# Altium schematic sheet MCU.SchDoc(MCU)
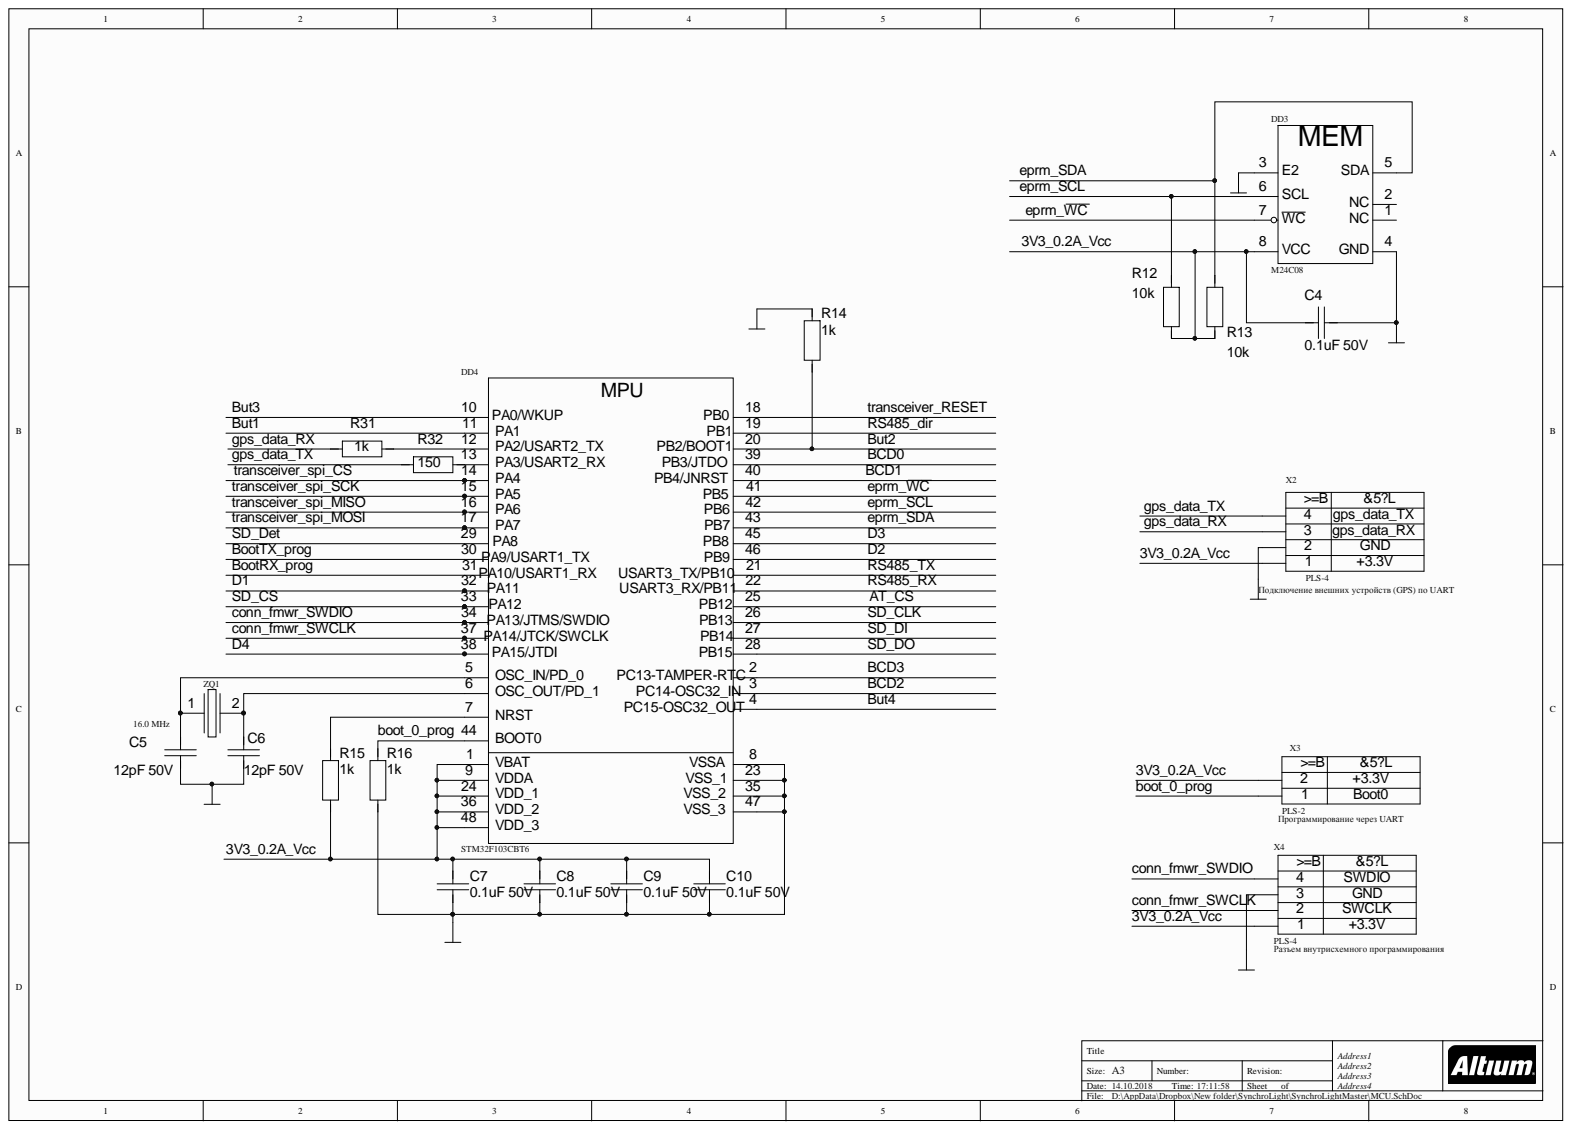
[diagram p0: MCU.SchDoc]

## Parts
C4  0.1uF 50V  [0805_CAP]
C5  12pF 50V  [0805_CAP]
C6  12pF 50V  [0805_CAP]
C7  0.1uF 50V  [0805_CAP]
C8  0.1uF 50V  [0805_CAP]
C9  0.1uF 50V  [0805_CAP]
C10  0.1uF 50V  [0805_CAP]
DD3  M24C08  [soic-8]
DD4  STM32F103CBT6  [Lqfp-48]
R12  10k  [0805_RES]
R13  10k  [0805_RES]
R14  1k  [0805_RES]
R15  1k  [0805_RES]
R16  1k  [0805_RES]
R31  1k  [0805_RES]
R32  150  [0805_RES]
X2  PLS-4  PinName4: gps_data_TX  PinName3: gps_data_RX  PinName2: GND  PinName1: +3.3V  Destiny: Подключение внешних устройств (GPS) по UART  [PLS-4-2.54]
X3  PLS-2  PinName2: +3.3V  PinName1: Boot0  Destiny: Программирование через UART  [PLS-2-2.54]
X4  PLS-4  PinName4: SWDIO  PinName3: GND  PinName2: SWCLK  PinName1: +3.3V  Destiny: Разъем внутрисхемного программирования  [PLS-4-2.54]
ZQ1  16.0 MHz  [HC-49]

## Nets
GND: C4.1 C5.1 C6.1 C7.1 C8.1 C9.1 C10.1 DD3.3(E2) DD3.4(GND) DD4.8(VSSA) DD4.23(VSS_1) DD4.35(VSS_2) DD4.47(VSS_3) R14.2 R16.1 X2.2 X4.3
3V3_0.2A_Vcc: C4.2 C7.2 C8.2 C9.2 C10.2 DD3.8(VCC) DD4.1(VBAT) DD4.9(VDDA) DD4.24(VDD_1) DD4.36(VDD_2) DD4.48(VDD_3) R12.1 R13.1 R15.1 X2.1 X3.2 X4.1
AT_CS: DD4.25(PB12)
BCD0: DD4.39(PB3/JTDO)
BCD1: DD4.40(PB4/JNRST)
BCD2: DD4.3
BCD3: DD4.2
boot_0_prog: DD4.44(BOOT0) R16.2 X3.1
BootRX_prog: DD4.31
BootTX_prog: DD4.30
But1: DD4.11(PA1)
But2: DD4.20(PB2/BOOT1) R14.1
But3: DD4.10(PA0/WKUP)
But4: DD4.4
conn_fmwr_SWCLK: DD4.37 X4.2
conn_fmwr_SWDIO: DD4.34(PA13/JTMS/SWDIO) X4.4
D1: DD4.32(PA11)
D2: DD4.46(PB9)
D3: DD4.45(PB8)
D4: DD4.38(PA15/JTDI)
eprm_SCL: DD3.6(SCL) DD4.42(PB6) R12.2
eprm_SDA: DD3.5(SDA) DD4.43(PB7) R13.2
eprm_~{WC}: DD3.7(WC) DD4.41(PB5)
gps_data_RX: R31.2 X2.3
gps_data_TX: R32.2 X2.4
RS485_dir: DD4.19(PB1)
RS485_RX: DD4.22
RS485_TX: DD4.21(USART3_TX/PB10)
SD_CLK: DD4.26(PB13)
SD_CS: DD4.33(PA12)
SD_Det: DD4.29(PA8)
SD_DI: DD4.27(PB14)
SD_DO: DD4.28(PB15)
transceiver_RESET: DD4.18(PB0)
transceiver_spi_CS: DD4.14(PA4)
transceiver_spi_MISO: DD4.16(PA6)
transceiver_spi_MOSI: DD4.17(PA7)
transceiver_spi_SCK: DD4.15(PA5)
NetC5_2: C5.2 DD4.5(OSC_IN/PD_0) ZQ1.1
NetC6_2: C6.2 DD4.6(OSC_OUT/PD_1) ZQ1.2
NetDD4_7: DD4.7(NRST) R15.2
NetDD4_12: DD4.12(PA2/USART2_TX) R31.1
NetDD4_13: DD4.13(PA3/USART2_RX) R32.1

Not connected: DD3 1(NC) 2(NC)

## Library data of the parts
C4-C10 = Cap_np: Component Kind: Standard; Library Name: Integrated_Library_tikhovikt.IntLib
  C4 C7-C10: Value: 0.1uF 50V
  C5 C6: Value: 12pF 50V
DD4 = STM32F103-48: Value: STM32F103CBT6; Component Kind: Standard; Library Name: Integrated_Library_tikhovikt.IntLib
R12-R16 R31 R32 = Res: Component Kind: Standard; Library Name: Integrated_Library_tikhovikt.IntLib
  R12 R13: Value: 10k
  R14-R16 R31: Value: 1k
  R32: Value: 150
X2 X4 = 4pin_conn: Value: PLS-4; Component Kind: Standard; Library Name: Integrated_Library_tikhovikt.IntLib
X3 = 2pin_conn: Value: PLS-2; Component Kind: Standard; Library Name: Integrated_Library_tikhovikt.IntLib
ZQ1 = Cristall: Value: 16.0 MHz; Component Kind: Standard; Library Name: Integrated_Library_tikhovikt.IntLib
DD3 = M24C08: Value: M24C08; Component Kind: Standard; Library Name: Integrated_Library_tikhovikt.IntLib
